# Altium schematic sheet LED.SchDoc(LED)
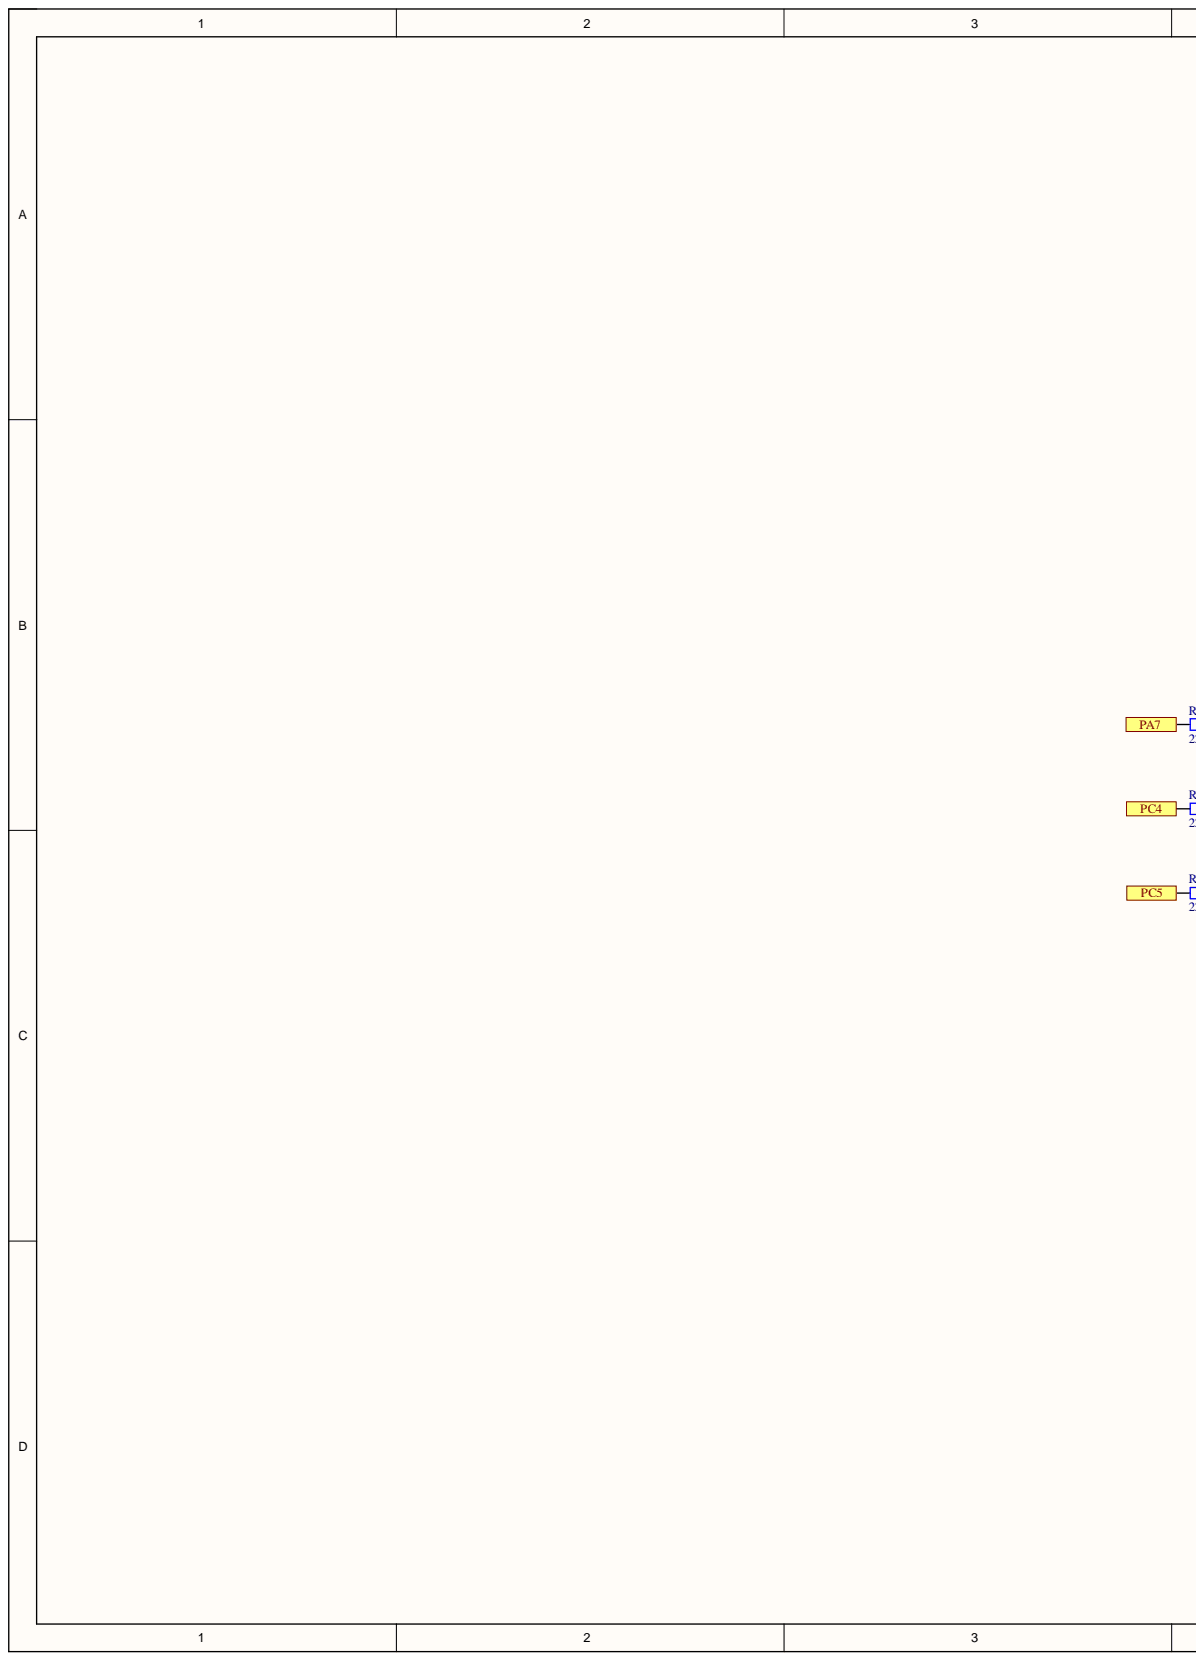
[diagram p0: LED.SchDoc, left]
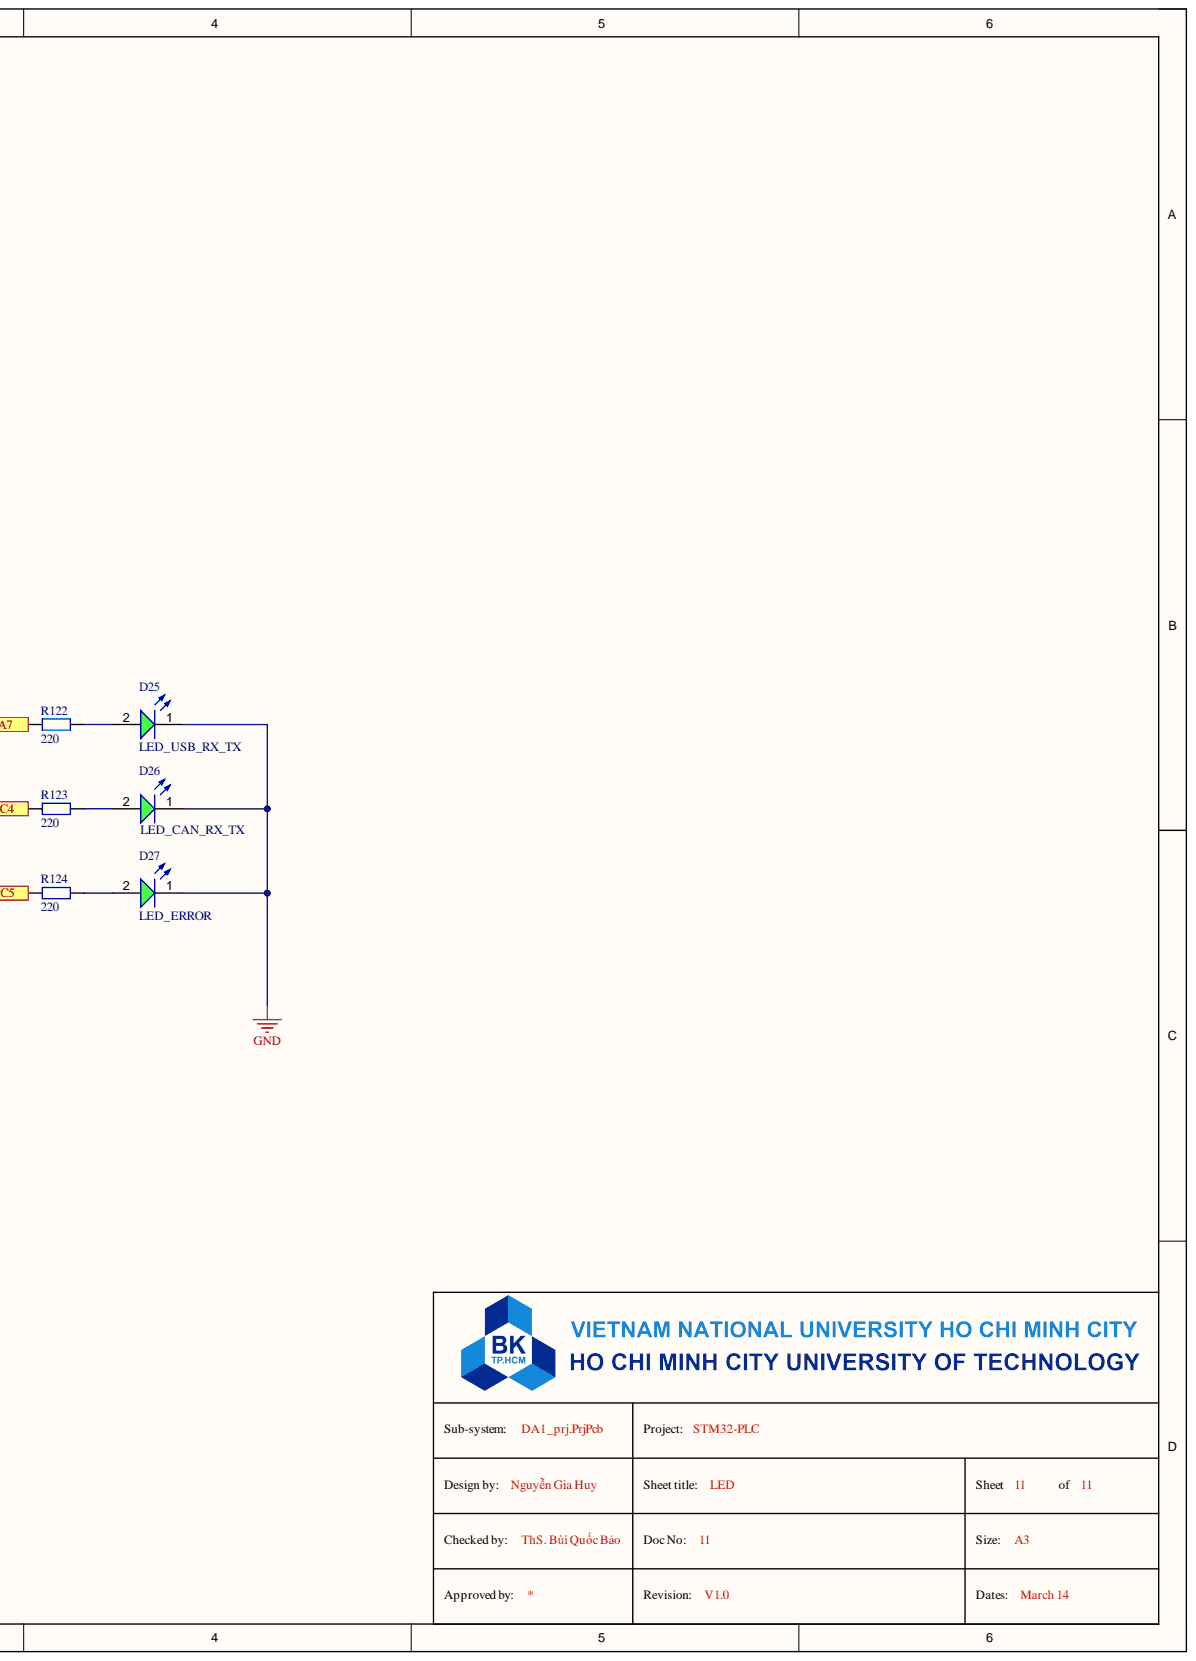
[diagram p1: LED.SchDoc, right]

## Parts
D25  LED_USB_RX_TX  [WL-SMCW_0603_150060xx75000]
D26  LED_CAN_RX_TX  [WL-SMCW_0603_150060xx75000]
D27  LED_ERROR  [WL-SMCW_0603_150060xx75000]
R122  220  [tro smd 0603]
R123  220  [tro smd 0603]
R124  220  [tro smd 0603]

## Ports
PA7, PC4, PC5

## Nets
GND: D25.1 D26.1 D27.1
PA7: R122.1 ; port PA7
PC4: R123.1 ; port PC4
PC5: R124.1 ; port PC5
NetD25_2: D25.2 R122.2
NetD26_2: D26.2 R123.2
NetD27_2: D27.2 R124.2

## Library data of the parts
D25-D27 = CMP-1426-00002-4: 430mcd Colorless transparence 520nm Emerald 140° LED0603 Light Emitting Diodes (LED) ROHS; Color: Green; Dominant Wavelength: 525nm; Forward Current: 100mA; Forward Voltage: 3.2V; Lens Style: Clear, Rectangular; Max Junction Temperature (Tj): 95°C; Max Reverse Voltage (DC): 5V; Output Current: 10µA; Peak Wavelength: 515nm; Power Dissipation: 105mW; Test Current: 20mA; Case Code (Imperial): 0603; Case Code (Metric): 1608; Case/Package: 0603; Component Kind: Standard; Height: 700µm; Lead Free: Lead Free; Length: 1.6mm; Luminous Intensity: 430mcd; Max Operating Temperature: 85°C; Min Operating Temperature: -40°C; Mount: Surface Mount; Packaging: Tape and Reel; REACH SVHC: Unknown; Reverse Voltage: 5V; RoHS: Compliant; Viewing Angle: 140°; Wavelength: 525nm; Width: 800µm; Library Name: AUSB.SchLib
R122-R124 = Tro 0603 1% 10k: RES; Chung_Loai: Dán; Don_Vi: Con; Ma_Hang: MH006333; So_Chan: 2; Ten_Linh_Kien: Trở 0603 1% 10k; Component Kind: Standard; Library Name: AUSB.SchLib
